# Altium schematic sheet 07_HDMI_1.SchDoc
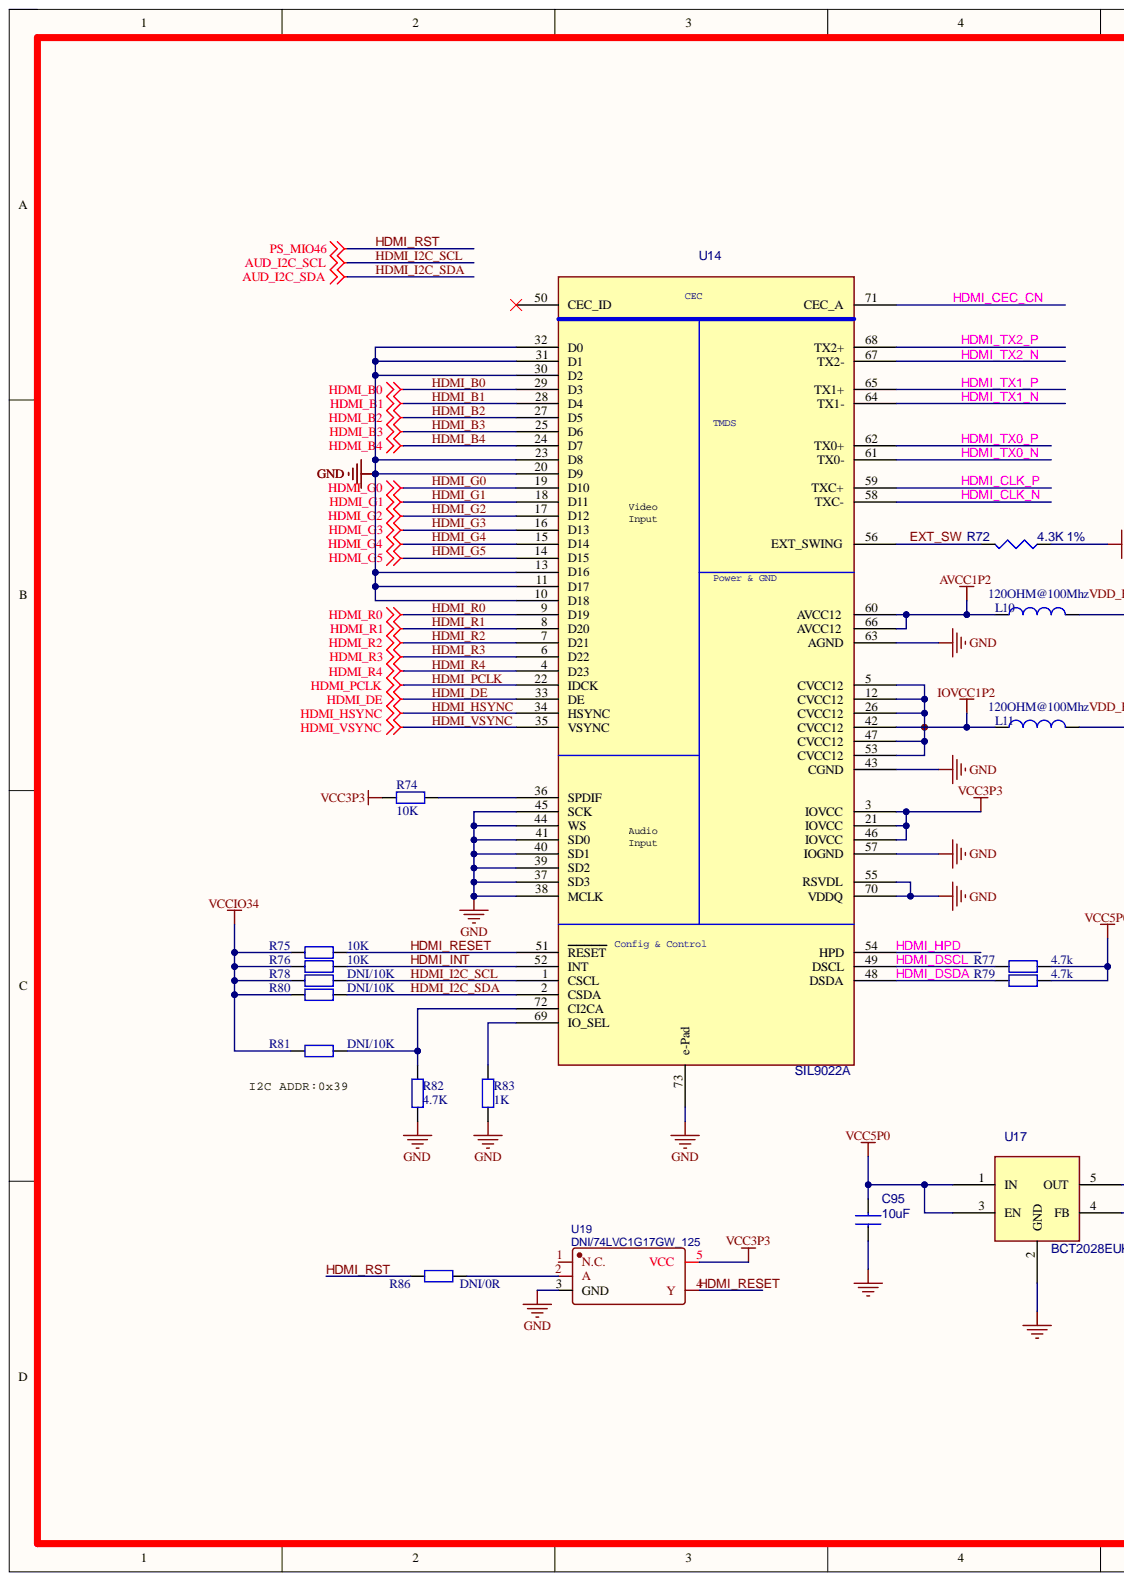
[diagram p0: 07_HDMI_1.SchDoc, left]
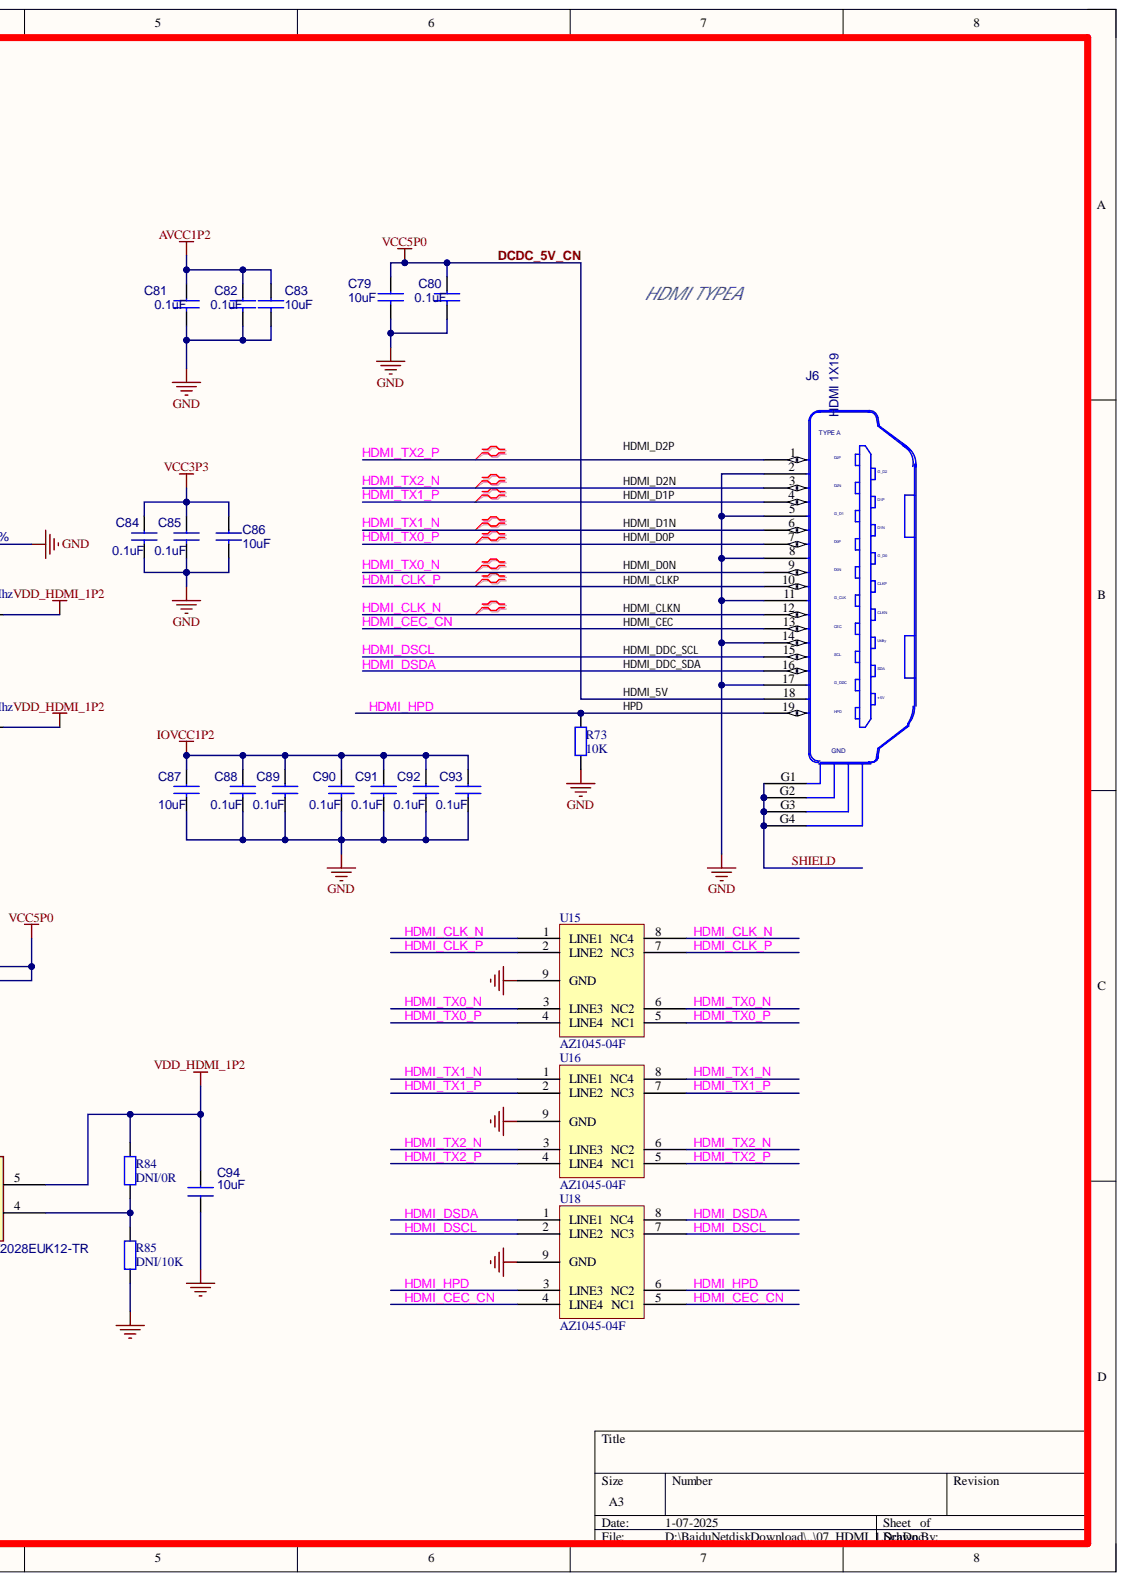
[diagram p1: 07_HDMI_1.SchDoc, right]

## Parts
C79  10uF  [C0805]
C80  0.1uF  [C0603]
C81  0.1uF  [C0603]
C82  0.1uF  [C0603]
C83  10uF  [C0805]
C84  0.1uF  [C0603]
C85  0.1uF  [C0603]
C86  10uF  [C0805]
C87  10uF  [C0805]
C88  0.1uF  [C0603]
C89  0.1uF  [C0603]
C90  0.1uF  [C0603]
C91  0.1uF  [C0603]
C92  0.1uF  [C0603]
C93  0.1uF  [C0603]
C94  10uF  [C0805]
C95  10uF  [C0805]
J6  HDMI 1X19  [HDMI_A]
L10  120OHM@100Mhz  [L0603]
L11  120OHM@100Mhz  [L0603]
R72  4.3K 1%  [0603R]
R73  10K  [0603R]
R74  10K  [0603R]
R75  10K  [0603R]
R76  10K  [0603R]
R77  4.7k  [0603R]
R78  DNI/10K  [0603R]
R79  4.7k  [0603R]
R80  DNI/10K  [0603R]
R81  DNI/10K  [0603R]
R82  4.7K  [0603R]
R83  1K  [0603R]
R84  DNI/0R  [0603R]
R85  DNI/10K  [0603R]
R86  DNI/0R  [0603R]
U14  SIL9022A  [QFN72_0P5_10X10_4P85SQ]
U15  AZ1045-04F  [SLP2710P8]
U16  AZ1045-04F  [SLP2710P8]
U17  BCT2028EUK12-TR  [SOT23-5]
U18  AZ1045-04F  [SLP2710P8]
U19  DNI/74LVC1G17GW_125  [SOT-353]

## Nets
AVCC1P2: C81.1 C82.1 C83.1 L10.1 U14.60(AVCC12) U14.66(AVCC12)
GND: C79.2 C80.2 C81.2 C82.2 C83.2 C84.2 C85.2 C86.2 C87.2 C88.2 C89.2 C90.2 C91.2 C92.2 C93.2 C94.2 C95.2 J6.2 J6.5 J6.8 J6.11 J6.14 J6.17 R72.1 R73.2 R82.2 R83.2 R85.2 U14.10(D18) U14.11(D17) U14.13(D16) U14.20(D9) U14.23(D8) U14.30(D2) U14.31(D1) U14.32(D0) U14.37(SD3) U14.38(MCLK) U14.39(SD2) U14.40(SD1) U14.41(SD0) U14.43(CGND) U14.44(WS) U14.45(SCK) U14.55(RSVDL) U14.57(IOGND) U14.63(AGND) U14.70(VDDQ) U14.73(e-Pad) U15.9(GND) U16.9(GND) U17.2(GND) U18.9(GND) U19.3(GND)
IOVCC1P2: C87.1 C88.1 C89.1 C90.1 C91.1 C92.1 C93.1 L11.1 U14.5(CVCC12) U14.12(CVCC12) U14.26(CVCC12) U14.42(CVCC12) U14.47(CVCC12) U14.53(CVCC12)
VCC3P3: C84.1 C85.1 C86.1 R74.2 U14.3(IOVCC) U14.21(IOVCC) U14.46(IOVCC) U19.5(VCC)
VCCIO34: R75.2 R76.2 R78.2 R80.2 R81.2
VDD_HDMI_1P2: C94.1 L10.2 L11.2 R84.1 U17.5(OUT)
DCDC_5V_CN: C79.1 C80.1 C95.1 J6.18 R77.1 R79.1 U17.1(IN) U17.3(EN)
EXT_SW: R72.2 U14.56(EXT_SWING)
HDMI_B0: U14.29(D3)
HDMI_B1: U14.28(D4)
HDMI_B2: U14.27(D5)
HDMI_B3: U14.25(D6)
HDMI_B4: U14.24(D7)
HDMI_CEC_CN: J6.13 U14.71(CEC_A) U18.4(LINE4) U18.5(NC1)
HDMI_CLK_N: J6.12 U14.58(TXC-) U15.1(LINE1) U15.8(NC4)
HDMI_CLK_P: J6.10 U14.59(TXC+) U15.2(LINE2) U15.7(NC3)
HDMI_DE: U14.33(DE)
HDMI_DSCL: J6.15 R77.2 U14.49(DSCL) U18.2(LINE2) U18.7(NC3)
HDMI_DSDA: J6.16 R79.2 U14.48(DSDA) U18.1(LINE1) U18.8(NC4)
HDMI_G0: U14.19(D10)
HDMI_G1: U14.18(D11)
HDMI_G2: U14.17(D12)
HDMI_G3: U14.16(D13)
HDMI_G4: U14.15(D14)
HDMI_G5: U14.14(D15)
HDMI_HPD: J6.19 R73.1 U14.54(HPD) U18.3(LINE3) U18.6(NC2)
HDMI_HSYNC: U14.34(HSYNC)
HDMI_I2C_SCL: R78.1 U14.1(CSCL)
HDMI_I2C_SDA: R80.1 U14.2(CSDA)
HDMI_INT: R76.1 U14.52(INT)
HDMI_PCLK: U14.22(IDCK)
HDMI_R0: U14.9(D19)
HDMI_R1: U14.8(D20)
HDMI_R2: U14.7(D21)
HDMI_R3: U14.6(D22)
HDMI_R4: U14.4(D23)
HDMI_RESET: R75.1 U14.51(RESET) U19.4(Y)
HDMI_RST: R86.2
HDMI_TX0_N: J6.9 U14.61(TX0-) U15.3(LINE3) U15.6(NC2)
HDMI_TX0_P: J6.7 U14.62(TX0+) U15.4(LINE4) U15.5(NC1)
HDMI_TX1_N: J6.6 U14.64(TX1-) U16.1(LINE1) U16.8(NC4)
HDMI_TX1_P: J6.4 U14.65(TX1+) U16.2(LINE2) U16.7(NC3)
HDMI_TX2_N: J6.3 U14.67(TX2-) U16.3(LINE3) U16.6(NC2)
HDMI_TX2_P: J6.1 U14.68(TX2+) U16.4(LINE4) U16.5(NC1)
HDMI_VSYNC: U14.35(VSYNC)
SHIELD: J6.G1 J6.G2 J6.G3 J6.G4
NetR74_1: R74.1 U14.36(SPDIF)
NetR81_1: R81.1 R82.1 U14.72(CI2CA)
NetR83_1: R83.1 U14.69(IO_SEL)
NetR84_2: R84.2 R85.1 U17.4(FB)
NetR86_1: R86.1 U19.2(A)

Not connected: U14 50(CEC_ID); U19 1(N.C.)

## Library data of the parts
C79 C83 C86 C87 = 10uFCapacitor16V10%1206: Capacitor 10uF 16V 10% Ceramic 0805; Mfr: AVX; MfrNum: 0805YD106KAT2A; PartName: 10uF16V10%SMD0805; TIIntPartnumber: 1C0085; Cost10K: 0.045; Cost100: 0.19; Vendor: Digikey; Component Kind: Standard; Descriptive_Text: Capacitor 10uF 16V 10% Ceramic 0805; DescriptiveText: Capacitor 10uF 16V 10% Ceramic 0805
C80-C82 C84 C85 C88-C93 = 0.1uFCapacitor16V10%0402: Capacitor 0.1uF 16V 10% 0402 X7R; Mfr: Kemet; MfrNum: C0402C104K4RACT; PartName: 0.1uF16V10%SMD0402; TIIntPartnumber: 1C0089; Cost10K: 0.0255; Cost100: 0.11; Vendor: Digikey; Component Kind: Standard; Descriptive_Text: Capacitor 0.1uF 16V 10% 0402 X7R; DescriptiveText: Capacitor 0.1uF 16V 10% 0402 X7R
C94 C95 = CAP NP: RoHS CHIP CAP 4.7uF +10/-10% X5R; Component Kind: Standard
J6 = ATOM010130010052_HDMI_1: CON 1X19 HDMI SMT 0.5MM 249H AU --; AGILE_PN: 211-79674; CAD_APPROVED: Yes; CLASS: IO; MFG_NAME01: SHENZHEN ATOM TECHNOLOGY CO.,LTD; MFG_PN01: HD119F-3A04202; OriginalSymbolOrigin: (-200,650); Vendor: Comtek; VPN: 1166-2C105-211; Component Kind: Standard; HEIGHT: 6.33MM; Library Name: zynq.SCHLIB; ROHS: Yes
L10 L11 = Inductor: Code_IEC: 1005; Value: 100ohm/1.2A; Code_JEITA: 0402; Component Kind: Standard; Description: Inductor; LatestRevisionDate: 29-May-2009; LatestRevisionNote: IPC-7351 Footprint Added.; Library Name: Miscellaneous Devices.IntLib; PackageDescription: Chip Inductor; PackageReference: 0402-A; Published: 8-Jun-2000; Publisher: Altium Limited
R72 = R0603_Dup1: Component Kind: Standard
R73-R86 = Res2: Resistor; Component Kind: Standard; LatestRevisionDate: 17-Jul-2002; LatestRevisionNote: Re-released for DXP Platform.; PackageReference: AXIAL-0.4; Published: 8-Jun-2000; Library Name: 元件封装库.pcblib; Publisher: Altium Limited
  R73-R76: Value: 10K
  R77 R79: Value: 4.7k
  R78 R80 R81 R85: Value: DNI/10K
  R82: Value: 4.7K
  R83: Value: 1K
  R84 R86: Value: DNI/0R
U14 = sil9022_2: Component Kind: Standard
U17 = TLV73333PDBVR_0: Component Kind: Standard
U19 = 74LVC1G17GW,125: BOM_Manufacturer: NXP Semicon; BOM_Paste Type: expand; Contributor: LCSC; BOM_Manufacturer Part: 74LVC1G17GW,125; BOM_Supplier: LCSC; BOM_Supplier Part: C124293; Component Kind: Standard; spicePre: U; spiceSymbolName: 74LVC1G17GW,125
U15 U16 U18 = AZ1045-04F: Component Kind: Standard; Library Name: Schlib1.SchLib
